# Altium schematic sheet buck_converter.SchDoc
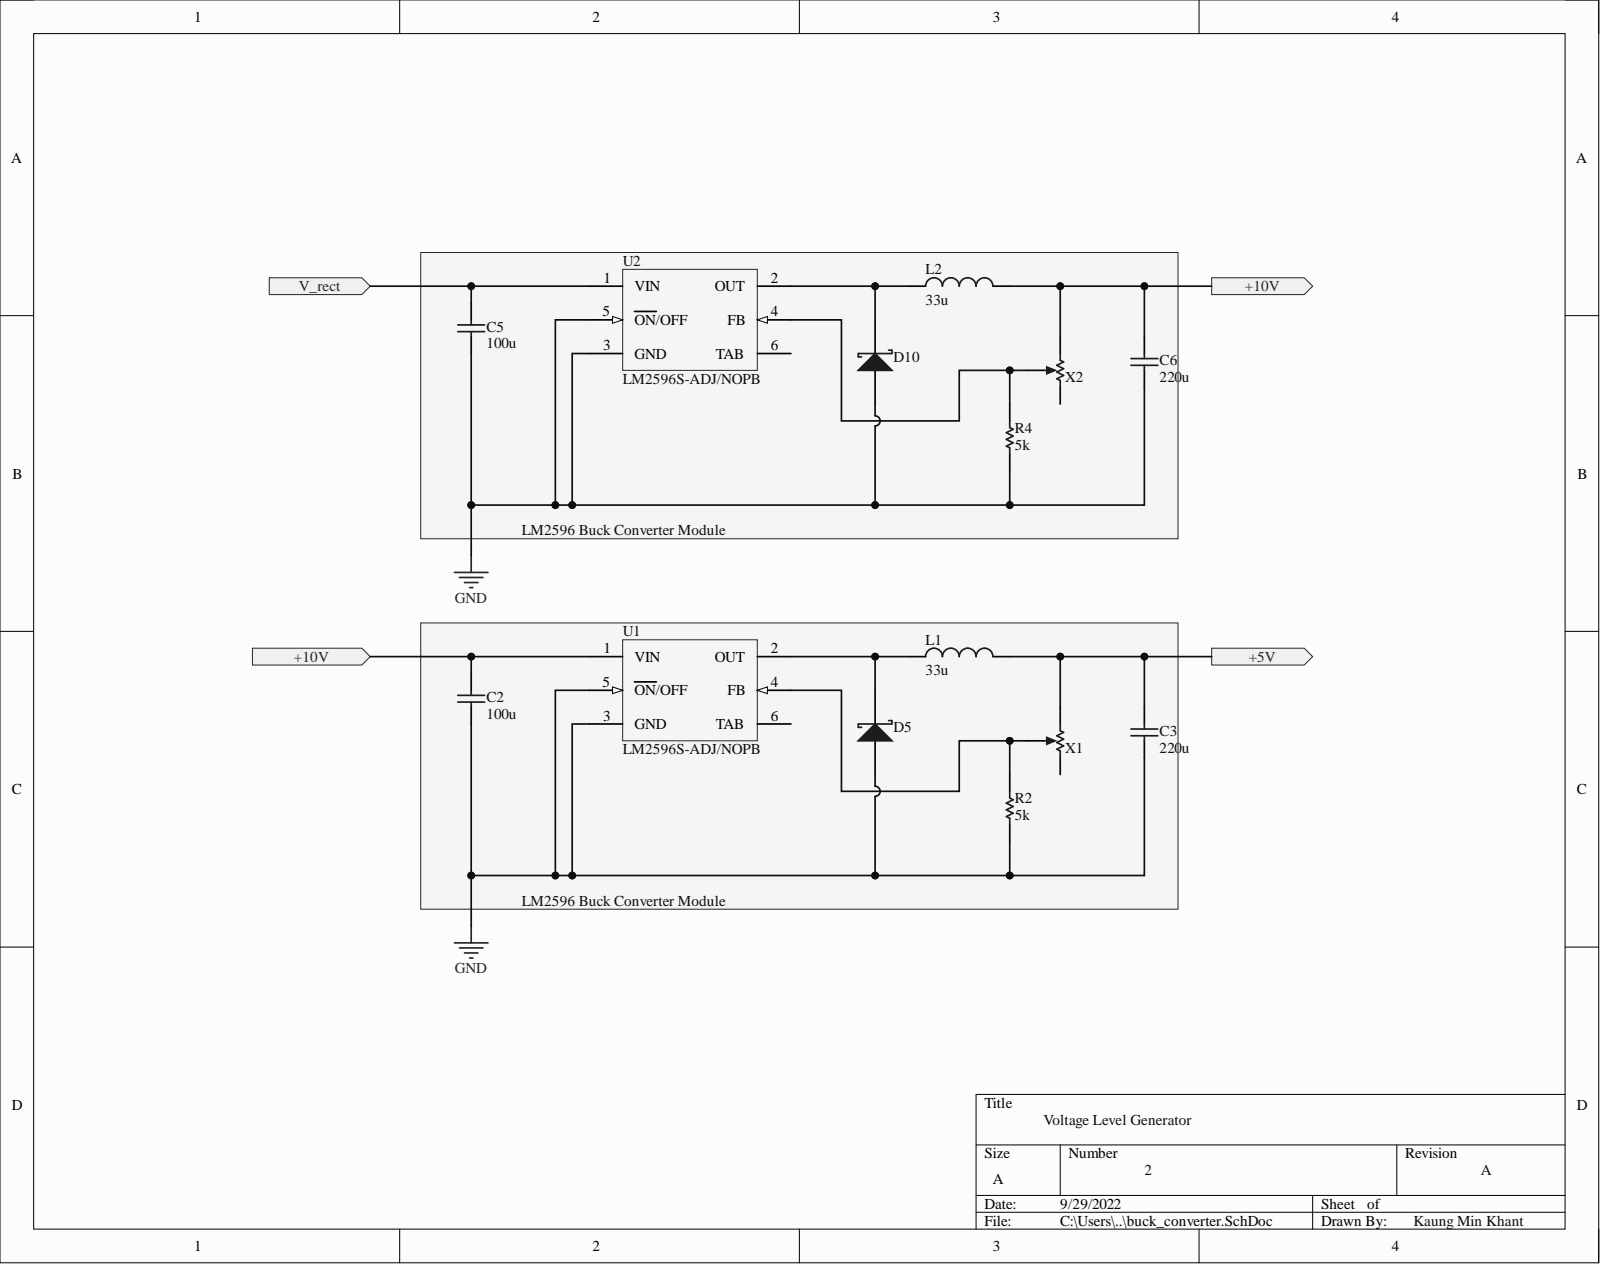
[diagram p0: buck_converter.SchDoc]

## Parts
C2  value 100u  (hidden comment: Capacitor)
C3  value 220u  (hidden comment: Capacitor)
C5  value 100u  (hidden comment: Capacitor)
C6  value 220u  (hidden comment: Capacitor)
D5  Shottky Diode  (value not drawn)
D10  Shottky Diode  (value not drawn)
L1  value 33u  (hidden comment: Inductor)
L2  value 33u  (hidden comment: Inductor)
R2  value 5k  (hidden comment: Resistor)
R4  value 5k  (hidden comment: Resistor)
U1  LM2596S-ADJ/NOPB  [KTT0005B_M]
U2  LM2596S-ADJ/NOPB  [KTT0005B_M]
X1  Potentiometer  (value not drawn)
X2  Potentiometer  (value not drawn)

## Ports
+5V, +10V, V_rect

## Nets
GND: C2.1 C3.1 C5.1 C6.1 D5.1 D10.1 R2.1 R4.1 U1.3(GND) U1.5(ON/OFF) U2.3(GND) U2.5(ON/OFF)
+5V: C3.2 L1.2 X1.1 ; port +5V
+10V: C2.2 C6.2 L2.2 U1.1(VIN) X2.1 ; port +10V
V_rect: C5.2 U2.1(VIN) ; port V_rect
NetD5_2: D5.2 L1.1 U1.2(OUT)
NetD10_2: D10.2 L2.1 U2.2(OUT)
NetR2_2: R2.2 U1.4(FB) X1.3
NetR4_2: R4.2 U2.4(FB) X2.3

Not connected: U1 6(TAB); U2 6(TAB); X1 2; X2 2

## Library data of the parts
C2 C3 C5 C6 = Capacitor: SimulationGenericComponent: True; Component Kind: Standard; Description: Capacitor; Library Name: Simulation Generic Components.IntLib; Simulation: C_Capacitor_Default
D5 D10 = Shottky Diode: AF: 1; BV: 0; BV_MAX: 1e99; EG: 1.11; FC: 0.5; IBV: 0.001; IS: 1e-14; MJSW: 0.33; NTUN: 30; SimulationGenericComponent: True; TNOM: 27; XTI: 3; CJO: 0; CJP: 0; Component Kind: Standard; CTA: 0; CTP: 0; Description: Shottky Diode; FCS: 0.5; FV_MAX: 1e99; IKF: 0; IKR: 0; ISR: 1e-14; JSW: 0; JTUN: 0; JTUNSW: 0; KEG: 1; KF: 0; LEVEL: 1; Library Name: Simulation Generic Components.IntLib; N: 1; NBV: 1; NR: 1; NS: 1; PHP: 1; RS: 0; Simulation: diode; TCV: 0; TLEV: 0; TLEVC: 0; TM1: 0; TM2: 0; TPB: 0; TPHP: 0; TRS: 0; TRS2: 0; TT: 0; TTT1: 0; TTT2: 0; VJ: 1; XTITUN: 3
L1 L2 = Inductor: SimulationGenericComponent: True; Component Kind: Standard; Description: Inductor; Library Name: Simulation Generic Components.IntLib; Simulation: L_Inductor_Default
R2 R4 = Resistor: SimulationGenericComponent: True; TC1: 0; Component Kind: Standard; Description: Resistor; Library Name: Simulation Generic Components.IntLib; Simulation: R_Resistor_Default; TC2: 0
U1 U2 = CMP-0069-00271-3: SIMPLE SWITCHER® Power Converter 150 KHz 3A Step-Down Voltage Regulator, 5-pin TO-263, Pb-Free; Manufacturer: Texas Instruments; PackageTopMarking: NSUZXYTTE#*LM2596S*-ADJ P+; PowerWise: N; Code_JEDEC: MO-169-AB; Component Kind: Standard; ComponentLink1Description: Datasheet URL; ComponentLink1URL: http://www.ti.com/general/docs/lit/getliterature.tsp?genericPartNumber=LM2596&fileType=pdf; CompType: Switcher; Library Name: Altium Content Vault; PackageDescription: TO-263, Molded, .400 x .390 x .180in, 5 Lead, .067in Pitch, Surface Mount; PackageReference: KTT0005B; PinCount: 5; RoHS: Y; Simulation: BUF_BASIC_GEN
X1 X2 = Potentiometer: position: 0.1; SimulationGenericComponent: True; value: 5k; Component Kind: Standard; Description: Potentiometer; Library Name: Simulation Generic Components.IntLib; Simulation: POT
